# Altium schematic sheet Power.SchDoc(Power)
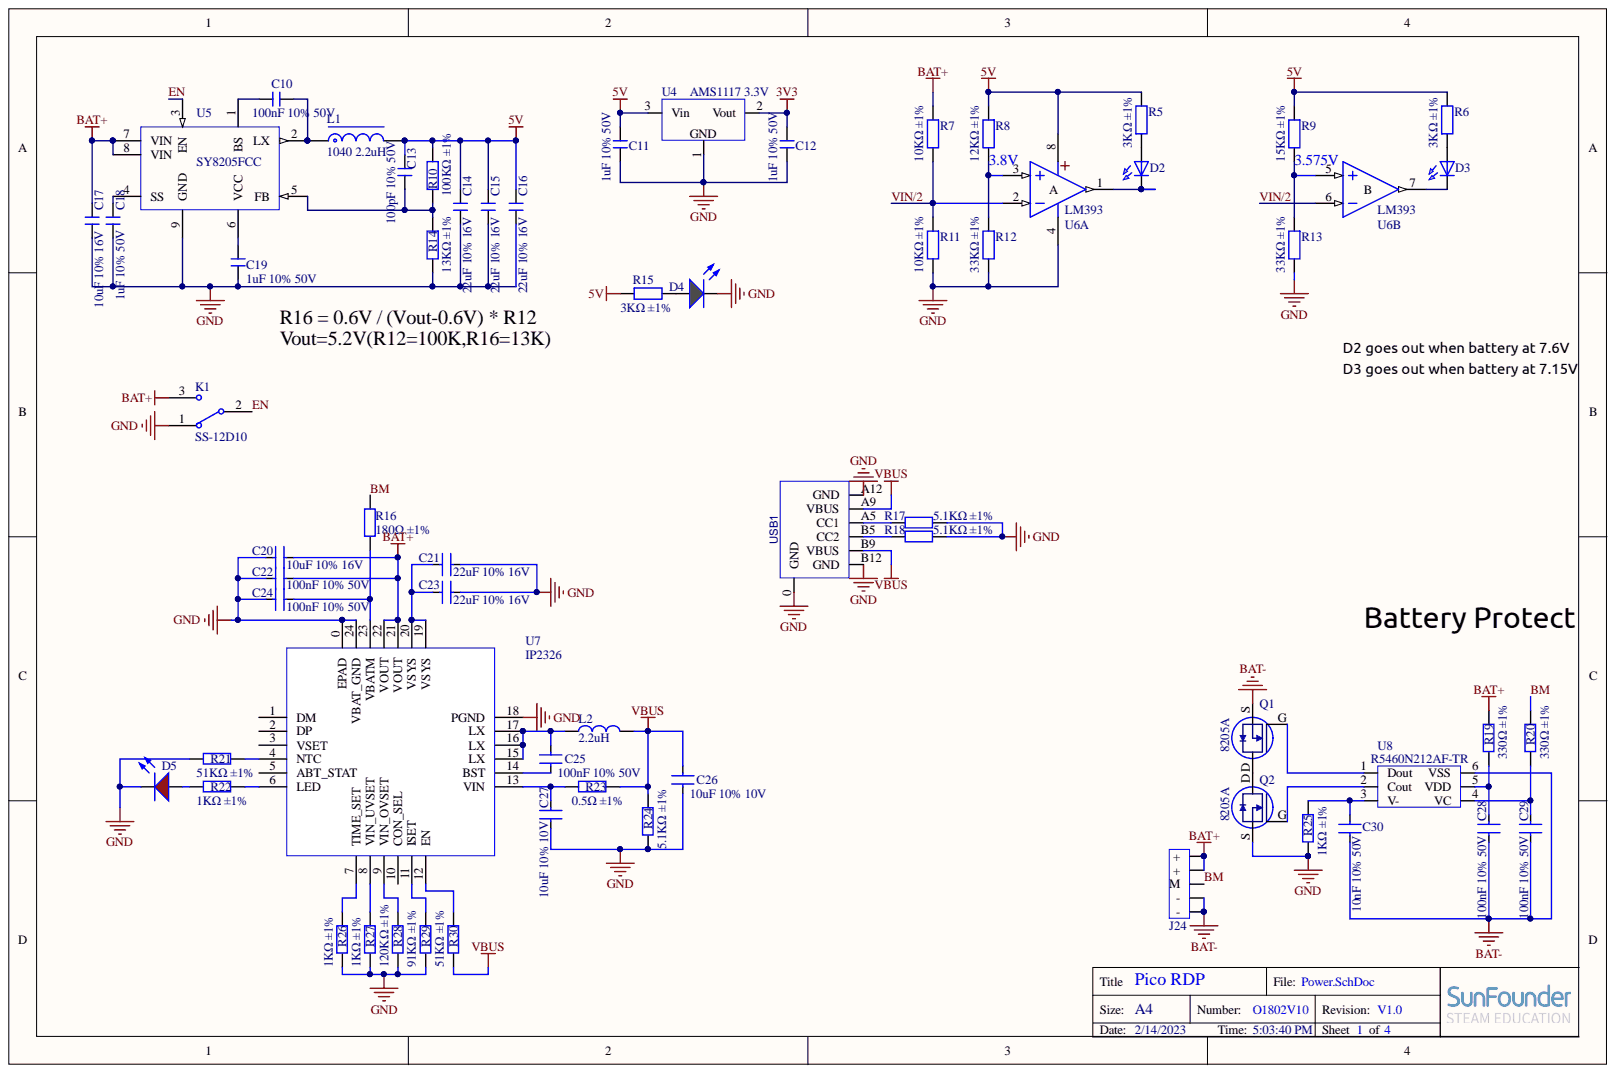
[diagram p0: Power.SchDoc]

## Parts
C10  100nF 10% 50V  [电容-0603]
C11  1uF 10% 50V  [电容-0603]
C12  1uF 10% 50V  [电容-0603]
C13  100pF 10% 50V  [电容-0603]
C14  22uF 10% 16V  [电容-0805]
C15  22uF 10% 16V  [电容-0805]
C16  22uF 10% 16V  [电容-0805]
C17  10uF 10% 16V  [电容-0805]
C18  1uF 10% 50V  [电容-0603]
C19  1uF 10% 50V  [电容-0603]
C20  10uF 10% 16V  [电容-0805]
C21  22uF 10% 16V  [电容-0805]
C22  100nF 10% 50V  [电容-0603]
C23  22uF 10% 16V  [电容-0805]
C24  100nF 10% 50V  [电容-0603]
C25  100nF 10% 50V  [电容-0603]
C26  10uF 10% 10V  [电容-0603]
C27  10uF 10% 10V  [电容-0603]
C28  100nF 10% 50V  [电容-0603]
C29  100nF 10% 50V  [电容-0603]
C30  10nF 10% 50V  [电容-0603]
D2  橙黄色  [LED 0603R]  (value not drawn)
D3  橙黄色  [LED 0603R]  (value not drawn)
D4  翠绿灯  [贴片 LED 0603 绿色]  (value not drawn)
D5  红灯  [贴片 LED 0603 红色]  (value not drawn)
J24  PH2.0 5P 直插 卧式  [PH2.0 5P 直插 卧式]  (value not drawn)
K1  SS-12D10  [拨动开关 6脚立式 两档/六脚]
L1  1040 2.2uH  [L-1040]
L2  2.2uH  [功率电感 0530]
Q1  8205A  [8205A]
Q2  8205A  [8205A]
R5  3KΩ ±1%  [电阻_0603]
R6  3KΩ ±1%  [电阻_0603]
R7  10KΩ ±1%  [电阻_0603]
R8  12KΩ ±1%  [电阻_0603]
R9  15KΩ ±1%  [电阻_0603]
R10  100KΩ ±1%  [电阻_0603]
R11  10KΩ ±1%  [电阻_0603]
R12  33KΩ ±1%  [电阻_0603]
R13  33KΩ ±1%  [电阻_0603]
R14  13KΩ ±1%  [电阻_0603]
R15  3KΩ ±1%  [电阻_0603]
R16  180Ω ±1%  [电阻_0603]
R17  5.1KΩ ±1%  [电阻_0603]
R18  5.1KΩ ±1%  [电阻_0603]
R19  330Ω ±1%  [电阻_0603]
R20  330Ω ±1%  [电阻_0603]
R21  51KΩ ±1%  [电阻_0603]
R22  1KΩ ±1%  [电阻_0603]
R23  0.5Ω ±1%  [电阻_0603]
R24  5.1KΩ ±1%  [电阻_0603]
R25  1KΩ ±1%  [电阻_0603]
R26  1KΩ ±1%  [电阻_0603]
R27  1KΩ ±1%  [电阻_0603]
R28  120KΩ ±1%  [电阻_0603]
R29  91KΩ ±1%  [电阻_0603]
R30  51KΩ ±1%  [电阻_0603]
U4  AMS1117 3.3V  [SOT223]
U5  SY8205FCC  [SOP-8-EP]
U6  LM393  [SOP-8]  (parts A,B)
U7  IP2326  [LCC24]
U8  R5460N212AF-TR  [SOT23-6]
USB1  USB TYPE C 6P 母座  [USB-TYPE-C-母座-6PIN]  (value not drawn)

## Nets
3V3: C12.2 U4.2
5V: C11.2 C13.2 C14.2 C15.2 C16.2 L1.2 R5.1 R6.1 R8.2 R9.2 R10.2 R15.2 U4.3 U6.8
BAT+: C17.2 C20.2 C22.2 J24.1(+) J24.2(+) K1.3 R7.2 R19.2 U5.7(VIN) U5.8(VIN) U7.21(VOUT) U7.22(VOUT)
BAT-: C28.1 C29.1 C30.1 J24.4(-) J24.5(-) Q1.S U8.6(VSS)
GND: C11.1 C12.1 C14.1 C15.1 C16.1 C17.1 C18.1 C19.1 C20.1 C21.2 C22.1 C23.2 C24.1 C26.2 C27.1 D4.K D5.K K1.1 Q2.S R11.1 R12.1 R13.1 R14.1 R17.1 R18.1 R21.2 R24.2 R25.1 R26.1 R27.1 R28.1 R29.1 U4.1 U5.9(GND) U6.4(A) U7.0(EPAD) U7.18(PGND) U7.24(VBAT_GND) USB1.0(GND) USB1.A12(GND) USB1.B12(GND)
VBUS: C26.1 L2.1 R23.1 R24.1 R30.1 USB1.A9(VBUS) USB1.B9(VBUS)
BM: J24.3(M) R16.1 R20.2
EN: K1.2 U5.3(EN)
VIN/2: R7.1 R11.2 U6.2 U6.6
NetC10_1: C10.1 L1.1 U5.2(LX)
NetC10_2: C10.2 U5.1(BS)
NetC13_1: C13.1 R10.1 R14.2 U5.5(FB)
NetC18_2: C18.2 U5.4(SS)
NetC19_2: C19.2 U5.6(VCC)
NetC21_1: C21.1 C23.1 U7.19(VSYS) U7.20(VSYS)
NetC24_2: C24.2 R16.2 U7.23(VBATM)
NetC25_1: C25.1 L2.2 U7.15(LX) U7.16(LX) U7.17(LX)
NetC25_2: C25.2 U7.14(BST)
NetC27_2: C27.2 R23.2 U7.13(VIN)
NetC28_2: C28.2 R19.1 U8.5(VDD)
NetC29_2: C29.2 R20.1 U8.4(VC)
NetC30_2: C30.2 R25.2 U8.3(V-)
NetD2_A: D2.A R5.2
NetD2_K: D2.K U6.1
NetD3_A: D3.A R6.2
NetD3_K: D3.K U6.7
NetD4_A: D4.A R15.1
NetD5_A: D5.A R22.2
NetQ1_D: Q1.D Q2.D
NetQ1_G: Q1.G U8.1(Dout)
NetQ2_G: Q2.G U8.2(Cout)
NetR8_1: R8.1 R12.2 U6.3
NetR9_1: R9.1 R13.2 U6.5
NetR17_2: R17.2 USB1.A5(CC1)
NetR18_2: R18.2 USB1.B5(CC2)
NetR21_1: R21.1 U7.4(NTC)
NetR22_1: R22.1 U7.6(LED)
NetR26_2: R26.2 U7.7(TIME_SET)
NetR27_2: R27.2 U7.8(VIN_UVSET)
NetR28_2: R28.2 U7.9(VIN_OVSET)
NetR29_2: R29.2 U7.11(ISET)
NetR30_2: R30.2 U7.12(EN)

Not connected: U7 1(DM) 2(DP) 3(VSET) 5(ABT_STAT) 10(CON_SEL)

## Library data of the parts
C10-C19 = C: 无极性贴片电容; 材质: X7R; 版权归属: 源创客; BOM名称: 无极性贴片电容; Component Kind: Standard; 学习资源: www.ooocke.com
  C10-C16 C18 C19: Library Name: ESP32 HAT.SchLib
  C17: Library Name: ESP32 RDP.SchLib
C20-C30 = Cap: 无极性贴片电容; Component Kind: Standard; Library Name: SunFounder_Library.IntLib
D2 D3 = LED: 发光二极管; Component Kind: Standard; Library Name: SunFounder_Library.IntLib
D4 = LED Green: 发光二极管; Component Kind: Standard; ComponentLink1Description: 供应商链接; ComponentLink1URL: http://www.szlcsc.com/product/details_2668.html; Package: 0805; Supplier: LC; SuppliersPartNumber: C2295; Library Name: SunFounder_Library.IntLib
D5 = LED Red: 发光二极管; Component Kind: Standard; ComponentLink1Description: 供应商链接; ComponentLink1URL: http://www.szlcsc.com/product/details_2668.html; Package: 0805; Supplier: LC; SuppliersPartNumber: C2295; Library Name: SunFounder_Library.IntLib
J24 = 2节18650电池5P: 5P接插件; BOM名称: 4P接插件; 版权归属: 源创客; Component Kind: Standard; Library Name: Others.SchLib; 学习资源: www.ooocke.com
K1 = SSW-1P: 1路波动开关; BOM名称: 波动开关; 版权归属: 源创客; Component Kind: Standard; Library Name: ESP32 HAT.SchLib; 学习资源: www.ooocke.com
L1 = L-1040: 一体化成型贴片功率电感; BOM名称: 功率电感; 版权归属: 源创客; Component Kind: Standard; 学习资源: www.ooocke.com; Library Name: ESP32 RDP.SchLib
L2 = Inductor: 一体化成型贴片功率电感; Component Kind: Standard; Library Name: SunFounder_Library.IntLib
Q1 Q2 = 8205A: 8205A 锂电池保护芯片; Component Kind: Standard; Library Name: SunFounder_Library.IntLib
R5-R15 = R: 贴片电阻; 版权归属: 源创客; BOM名称: 贴片电阻; Component Kind: Standard; Library Name: ESP32 HAT.SchLib; 学习资源: www.ooocke.com
R16-R30 = Res: 贴片电阻; Component Kind: Standard; Library Name: SunFounder_Library.IntLib
U4 = AMS1117: 线性稳压芯片; BOM名称: 稳压芯片; 版权归属: 源创客; Component Kind: Standard; 学习资源: www.ooocke.com; Library Name: SunFounder_Library.IntLib
U5 = SY8205FCC: DC降压芯片，5A; BOM名称: DC降压芯片; 描述: IN:28V,OUT:0.8-25/3A,360MHz; 版权归属: 源创客; Component Kind: Standard; 学习资源: www.ooocke.com; Library Name: SunFounder_Library.IntLib
U6 = LM393: 双路电压比较; 版权归属: 源创客; BOM名称: 双路电压比较; Component Kind: Standard; Library Name: ESP32 HAT.SchLib; 学习资源: www.ooocke.com
U8 = R5460N212AF: R5460N212AF-TR 双锂电池保护芯片; Component Kind: Standard; Value: R5460N212AF-TR; Library Name: SunFounder_Library.IntLib
USB1 = USB TYPE C 6P 母座: 联系卖家 免费查找封装: 唯一出售店铺网址https://shop463601444.taobao.com; Component Kind: Standard; Description: USB TYPE C 6P 母座; Library Name: SunFounder_Library.IntLib
U7 = IP2326: Component Kind: Standard; Description: IP2326; Library Name: SunFounder_Library.IntLib
